# Altium schematic sheet rpi_adapter_2x4L_sink.SchDoc
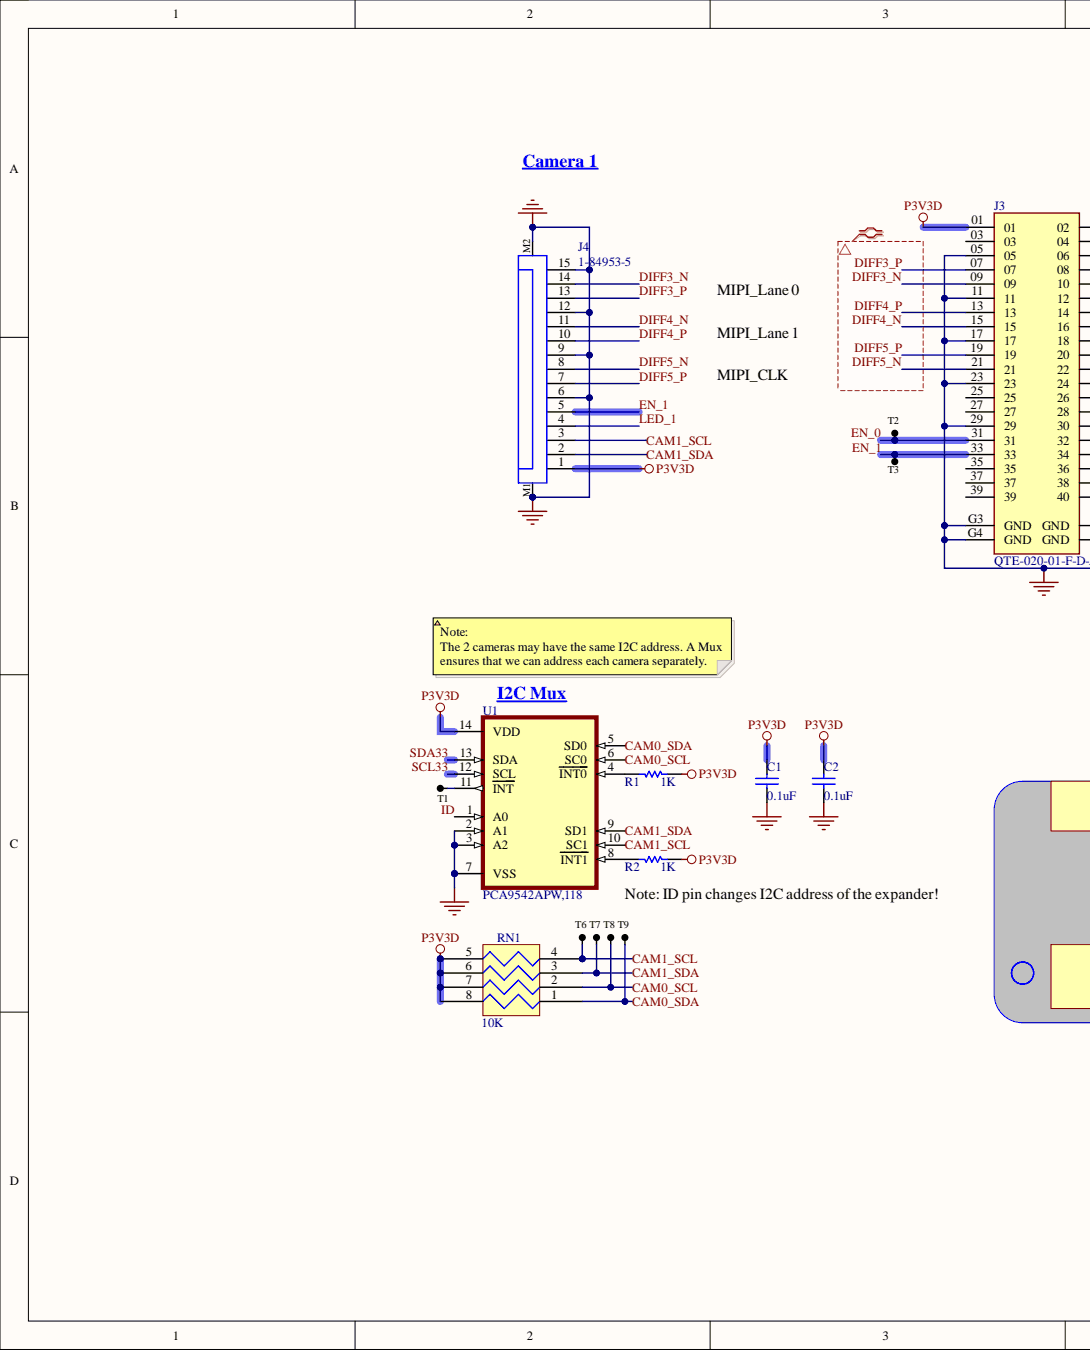
[diagram p0: rpi_adapter_2x4L_sink.SchDoc, left]
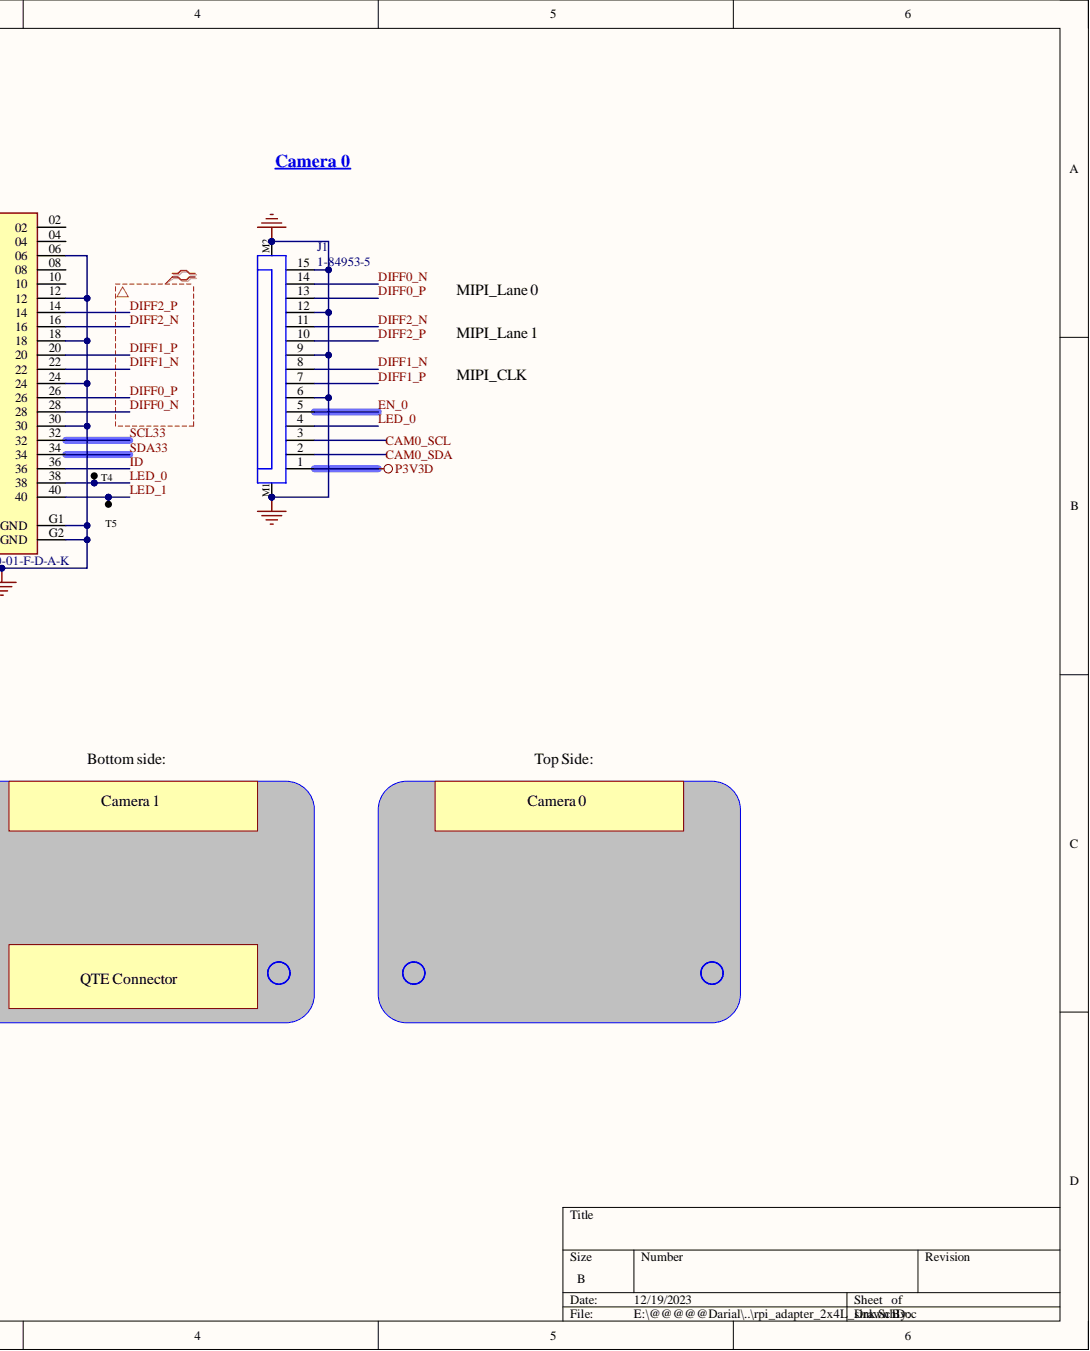
[diagram p1: rpi_adapter_2x4L_sink.SchDoc, right]

## Parts
C1  0.1uF  [CAPC1005X55USR]
C2  0.1uF  [CAPC1005X55USR]
J1  1-84953-5  [TE_1-84953-5]
J3  QTE-020-01-F-D-A-K  [SAMTEC_QTE-020-01-X-D-A]
J4  1-84953-5  [TE_1-84953-5]
R1  1K  [RESC1005X40USR]
R2  1K  [RESC1005X40USR]
RN1  10K  [RESCAXS50P100X200X45-8NA]
T1  TP_S  [TP 1mm]  (value not drawn)  (no BOM)
T2  TP_S  [TP 1mm]  (value not drawn)  (no BOM)
T3  TP_S  [TP 1mm]  (value not drawn)  (no BOM)
T4  TP_S  [TP 1mm]  (value not drawn)  (no BOM)
T5  TP_S  [TP 1mm]  (value not drawn)  (no BOM)
T6  TP_S  [TP 1mm]  (value not drawn)  (no BOM)
T7  TP_S  [TP 1mm]  (value not drawn)  (no BOM)
T8  TP_S  [TP 1mm]  (value not drawn)  (no BOM)
T9  TP_S  [TP 1mm]  (value not drawn)  (no BOM)
U1  PCA9542APW,118  [SOP65P640X110-14N]

## Nets
GND: C1.1 C2.1 J1.6 J1.9 J1.12 J1.15 J1.M1 J1.M2 J3.05 J3.06 J3.11 J3.12 J3.17 J3.18 J3.23 J3.24 J3.29 J3.30 J3.G1(GND) J3.G2(GND) J3.G3(GND) J3.G4(GND) J4.6 J4.9 J4.12 J4.15 J4.M1 J4.M2 U1.2(A1) U1.3(A2) U1.7(VSS)
P3V3D: C1.2 C2.2 J1.1 J3.01 J4.1 R1.2 R2.2 RN1.5 RN1.6 RN1.7 RN1.8 U1.14(VDD)
CAM0_SCL: J1.3 RN1.2 T8.1 U1.6(SC0)
CAM0_SDA: J1.2 RN1.1 T9.1 U1.5(SD0)
CAM1_SCL: J4.3 RN1.4 T6.1 U1.10(SC1)
CAM1_SDA: J4.2 RN1.3 T7.1 U1.9(SD1)
DIFF0_N: J1.14 J3.28
DIFF0_P: J1.13 J3.26
DIFF1_N: J1.8 J3.22
DIFF1_P: J1.7 J3.20
DIFF2_N: J1.11 J3.16
DIFF2_P: J1.10 J3.14
DIFF3_N: J3.09 J4.14
DIFF3_P: J3.07 J4.13
DIFF4_N: J3.15 J4.11
DIFF4_P: J3.13 J4.10
DIFF5_N: J3.21 J4.8
DIFF5_P: J3.19 J4.7
EN_0: J1.5 J3.31 T2.1
EN_1: J3.33 J4.5 T3.1
ID: J3.36 U1.1(A0)
LED_0: J1.4 J3.38 T4.1
LED_1: J3.40 J4.4 T5.1
SCL33: J3.32 U1.12(SCL)
SDA33: J3.34 U1.13(SDA)
NetR1_1: R1.1 U1.4(INT0)
NetR2_1: R2.1 U1.8(INT1)
NetT1_1: T1.1 U1.11(INT)

Not connected: J3 02 03 04 08 10 25 27 35 37 39

## Library data of the parts
C1 C2 = CAP CER 0.1UF 16V X7R 0402: Manufacturer: TDK Corporation; Manufacturer Part Number: CGA2B1X7R1C104K050BC; Component Kind: Standard; Description: CAP CER 0.1UF 16V X7R 0402; Height: 0.55mm; Library Name: NX Module baseboard.SCHLIB; Package: 0402; Supplier 1: Digi-Key; Supplier Part Number 1: 445-5613-2-ND; value: 0.1uF
J1 J4 = FPC - 15P - 2MP: Conn FPC Connector SKT 15 POS 1mm Solder RA SMD T/R; Manufacturer: TE Connectivity; Actuator Color: Black; Actuator Material: Nylon,Glass,Polyamide; Connector System: Flex-to-Board; Connector Type: Receptacle,Connector,Socket; Contact Current Rating: 1A; Contact Location: Top; Gender: Receptacle; Mating Alignment: Yes (With); Max Voltage Rating (AC): 200V; Number of Contacts: 15; PCB Mount Alignment: No (Without); PCB Mount Retention: With; PCB Mounting Orientation: Right Angle; Pitch: 0.039inch; Plating Thickness: 2um; Shielding: Unshielded; Wire/Cable Type: Flat Flex; Category: FFC / FPC; Component Kind: Standard; ComponentLink1Description: https://datasheet.ciiva.com/669/eng-cd-84953-a3-669110.pdf?src-supplier=Digi-Key; ComponentLink1URL: https://datasheet.ciiva.com/669/eng-cd-84953-a3-669110.pdf?src-supplier=Digi-Key; ComponentLink2Description: https://datasheet.ciiva.com/669/eng-cd-84953-a3-669111.pdf?src-supplier=Digi-Key; ComponentLink2URL: https://datasheet.ciiva.com/669/eng-cd-84953-a3-669111.pdf?src-supplier=Digi-Key; ComponentLink3Description: https://datasheet.ciiva.com/669/eng-cd-84953-a3-669112.pdf?src-supplier=Digi-Key; ComponentLink3URL: https://datasheet.ciiva.com/669/eng-cd-84953-a3-669112.pdf?src-supplier=Digi-Key; Contact Material: Bronze; Contact Plating: Tin,Bright; Current Rating: 1A; Depth: 7.3mm; ELV: Compliant; Flammability Rating: UL94 V-0; Height: 2.56mm; Housing Color: Natural; Housing Material: Polymer; Length: 22.92mm; Library Name: rpi_adapter.SCHLIB; Manufacturer Part Number: 1-84953-5; Max Operating Temperature: 85°C; Min Operating Temperature: -40°C; Mount: Surface Mount; Number of Positions: 15; Number of Rows: 1; Orientation: Right Angle; Package Quantity: 1200; Packaging: Tape and Reel; Pricing 1: 1=1.02000, 10=0.97700, 25=0.93400, 50=0.92100, 100=0.80470, 250=0.77460, 500=0.73158 (USD); Radiation Hardening: No; RoHS: Yes; RoHS Compliant: Yes; Sealable: No (Without); Series: FPC; Shrouded: Yes (With); Stock 1: 9836; Supplier 1: Digi-Key; Supplier Part Number 1: A101405CT-ND; Termination: SMD/SMT; Voltage Rating: 200V; Width: 0.2575inch
J3 = QSE-020-01-F-D-A-K: Q Strip® High-Speed Ground Plane Header, 0.80mm Pitch; Manufacturer: Samtec; Gender: Male; Mated Stacking Heights: 5mm; Number of Contacts: 40; Pitch: 0.031inch; Category: Board to Board Connectors; Component Kind: Standard; ComponentLink1Description: https://datasheet.ciiva.com/6924/qte-xxx-xx-x-d-xxx-mkt-6924765.pdf?src-supplier=Digi-Key; ComponentLink1URL: https://datasheet.ciiva.com/6924/qte-xxx-xx-x-d-xxx-mkt-6924765.pdf?src-supplier=Digi-Key; Contact Plating: Gold; Library Name: NX Module baseboard.SchLib; Manufacturer Part Number: QTE-020-01-F-D-A; Mounting Technology: Surface Mount; Number of Positions: 40; Number of Rows: 2; Package Quantity: 1; Packaging: Tray; Pricing: 1=5.61000, 10=5.09400, 25=4.82480, 40=4.71275, 80=4.48825, 230=3.92726, 440=3.81505, 945=3.25402, 2400=3.02960 (USD); RoHS: Yes; RoHS Compliant: Yes; Series: QStrip® QTE; Stock: 179; Supplier 1: Digi-Key; Supplier Part Number 1: SAM8846-ND; Termination: Solder
R1 R2 = RES 1K OHM 1% 1/16W 0402: RES SMD 1K OHM 1% 1/16W 0402; Manufacturer: YAGEO; Manufacturer Part Number: RC0402FR-071KL; Component Kind: Standard; Height: 0.4mm; Package: 0402; Supplier 1: Digi-Key; Supplier Part Number 1: 311-1.00KLRCT-ND; value: 1K; Library Name: Tiger_Ti180.SCHLIB
RN1 = RES ARRAY 4 RES 47K OHM 0804: Res Thick Film Array 10K Ohm 5% ±200ppm/°C ISOL 8-Pin 0804(4 X 0402) Convex SMD Automotive T/R; Manufacturer: Panasonic; Manufacturer Part Number: EXB-28V103JX; Circuit Type: Isolated; Number of Contacts: 8; Number of Elements: 4; Power Dissipation: 63mW; Power Per Element: 62.5mW; Voltage Rating: 50V; Voltage Rating (DC): 100V; Case Code (Imperial): 0402; Case Code (Metric): 1005; Case/Package: 0804; Category: Resistor Arrays; Component Kind: Standard; ComponentLink1Description: https://datasheet.ciiva.com/46387/1480003-46387949.pdf?src-supplier=Element14; ComponentLink1URL: https://datasheet.ciiva.com/46387/1480003-46387949.pdf?src-supplier=Element14; ComponentLink2Description: https://datasheet.ciiva.com/46376/1480003-46376265.pdf?src-supplier=Element14; ComponentLink2URL: https://datasheet.ciiva.com/46376/1480003-46376265.pdf?src-supplier=Element14; ComponentLink3Description: https://datasheet.ciiva.com/28418/1790599-28418558.pdf?src-supplier=Element14; ComponentLink3URL: https://datasheet.ciiva.com/28418/1790599-28418558.pdf?src-supplier=Element14; ComponentLink4Description: https://datasheet.ciiva.com/28636/1790599-28636885.pdf?src-supplier=Element14; ComponentLink4URL: https://datasheet.ciiva.com/28636/1790599-28636885.pdf?src-supplier=Element14; ComponentLink5Description: https://datasheet.ciiva.com/30209/aoc0000ce1-30209125.pdf?src-supplier=Onlinecomponents.com; ComponentLink5URL: https://datasheet.ciiva.com/30209/aoc0000ce1-30209125.pdf?src-supplier=Onlinecomponents.com; Depth: 1mm; Height: 0.35mm; Lead Free: Lead Free; Length: 2mm; Library Name: NX Module.SCHLIB; Max Operating Temperature: 125°C; Military Standard: Not; Min Operating Temperature: -55°C; Mounting Technology: Surface Mount; Number of Resistors: 4; Package Quantity: 1; Packaging: Tape and Reel; Pins: 8; Pricing: 1=0.10000, 10=0.08100, 25=0.05640, 50=0.04380, 100=0.03130, 250=0.02444, 500=0.01942, 1000=0.01378, 5000=0.01065 (USD); Radiation Hardening: No; Reach SVHC Compliant: Unknown; Resistance Tolerance: 5%; RoHS: Yes; RoHS Compliant: Yes; Series: EXB; Stock: 1570078; Supplier 1: Digi-Key; Supplier Part Number 1: Y7103CT-ND; Technology: THICK FILM; Temperature Coefficient: 200ppm/°C; Terminal Pitch: 0.5mm; Termination: Convex; Tolerance: 5%; Value: 10K; Width: 1mm
T1-T9 = TP_S: test point; Library Name: AiC.SCHLIB
U1 = PCA9542APW,118: PCA9542A Series 2-Channel I2C-bus Multiplexer with Interrupt Logic - TSSOP - 14; Manufacturer: NXP Semiconductors; Clock Frequency: 400kHz; High Level Output Current: -10uA; Interface: I2C,SMBus; Low Level Output Current: 10mA; Max Supply Current: 25uA; Max Supply Voltage: 5.5V; Min Supply Voltage: 2.3V; Nominal Supply Voltage: 3.6V; Number of Channels: 2; Number of Output Lines: 1; Operating Supply Voltage: 5V; Power Dissipation: 400mW; Propagation Delay: 0.3ns; Supply Current: 100mA; Case/Package: TSSOP; Category: Interface ICs; Component Kind: Standard; ComponentLink1Description: https://datasheet.ciiva.com/4806/pca9542a-185703-4806721.pdf?src-supplier=Mouser; ComponentLink1URL: https://datasheet.ciiva.com/4806/pca9542a-185703-4806721.pdf?src-supplier=Mouser; ComponentLink2Description: https://datasheet.ciiva.com/15087/getdatasheetpartid-214981-15087231.pdf?src-supplier=Verical; ComponentLink2URL: https://datasheet.ciiva.com/15087/getdatasheetpartid-214981-15087231.pdf?src-supplier=Verical; ComponentLink3Description: https://datasheet.ciiva.com/8242/pca9542a-8242759.pdf?src-supplier=Avnet; ComponentLink3URL: https://datasheet.ciiva.com/8242/pca9542a-8242759.pdf?src-supplier=Avnet; ComponentLink4Description: https://datasheet.ciiva.com/1784/pca9542a-1784041.pdf?src-supplier=Digi-Key; ComponentLink4URL: https://datasheet.ciiva.com/1784/pca9542a-1784041.pdf?src-supplier=Digi-Key; ComponentLink5Description: https://datasheet.ciiva.com/1784/pca9542a-1784042.pdf?src-supplier=Digi-Key; ComponentLink5URL: https://datasheet.ciiva.com/1784/pca9542a-1784042.pdf?src-supplier=Digi-Key; ComponentLink6Description: https://datasheet.ciiva.com/1784/pca9542a-1784043.pdf?src-supplier=Digi-Key; ComponentLink6URL: https://datasheet.ciiva.com/1784/pca9542a-1784043.pdf?src-supplier=Digi-Key; ComponentLink7Description: https://datasheet.ciiva.com/16219/pca9542a-16219928.pdf?src-supplier=Future+Electronics; ComponentLink7URL: https://datasheet.ciiva.com/16219/pca9542a-16219928.pdf?src-supplier=Future+Electronics; Height: 0.95mm; Lead Free: Lead Free; Length: 5.1mm; Library Name: tinyCamera.SCHLIB; Logic Function: Multiplexer; Manufacturer Part Number: PCA9542APW,118; Max Operating Temperature: 85°C; Min Operating Temperature: -40°C; Mounting Technology: Surface Mount; Number of Input Lines: 2; Number of Inputs: 2; Number of Outputs: 1; Package Quantity: 1; Packaging: Tape and Reel; Pins: 14; Pricing: 1=2.50000, 10=2.24900, 25=2.12160, 100=1.80750, 250=1.69720, 500=1.48506, 1000=1.23047 (USD); Radiation Hardening: No; RoHS: Yes; RoHS Compliant: Yes; Series: -; Stock: 18695; Supplier 1: Digi-Key; Supplier Part Number 1: 568-1856-1-ND; Weight: 0.004949oz; Width: 4.5mm
